# Altium schematic sheet RF19_Sensor_CAN_Module_MCU.SchDoc
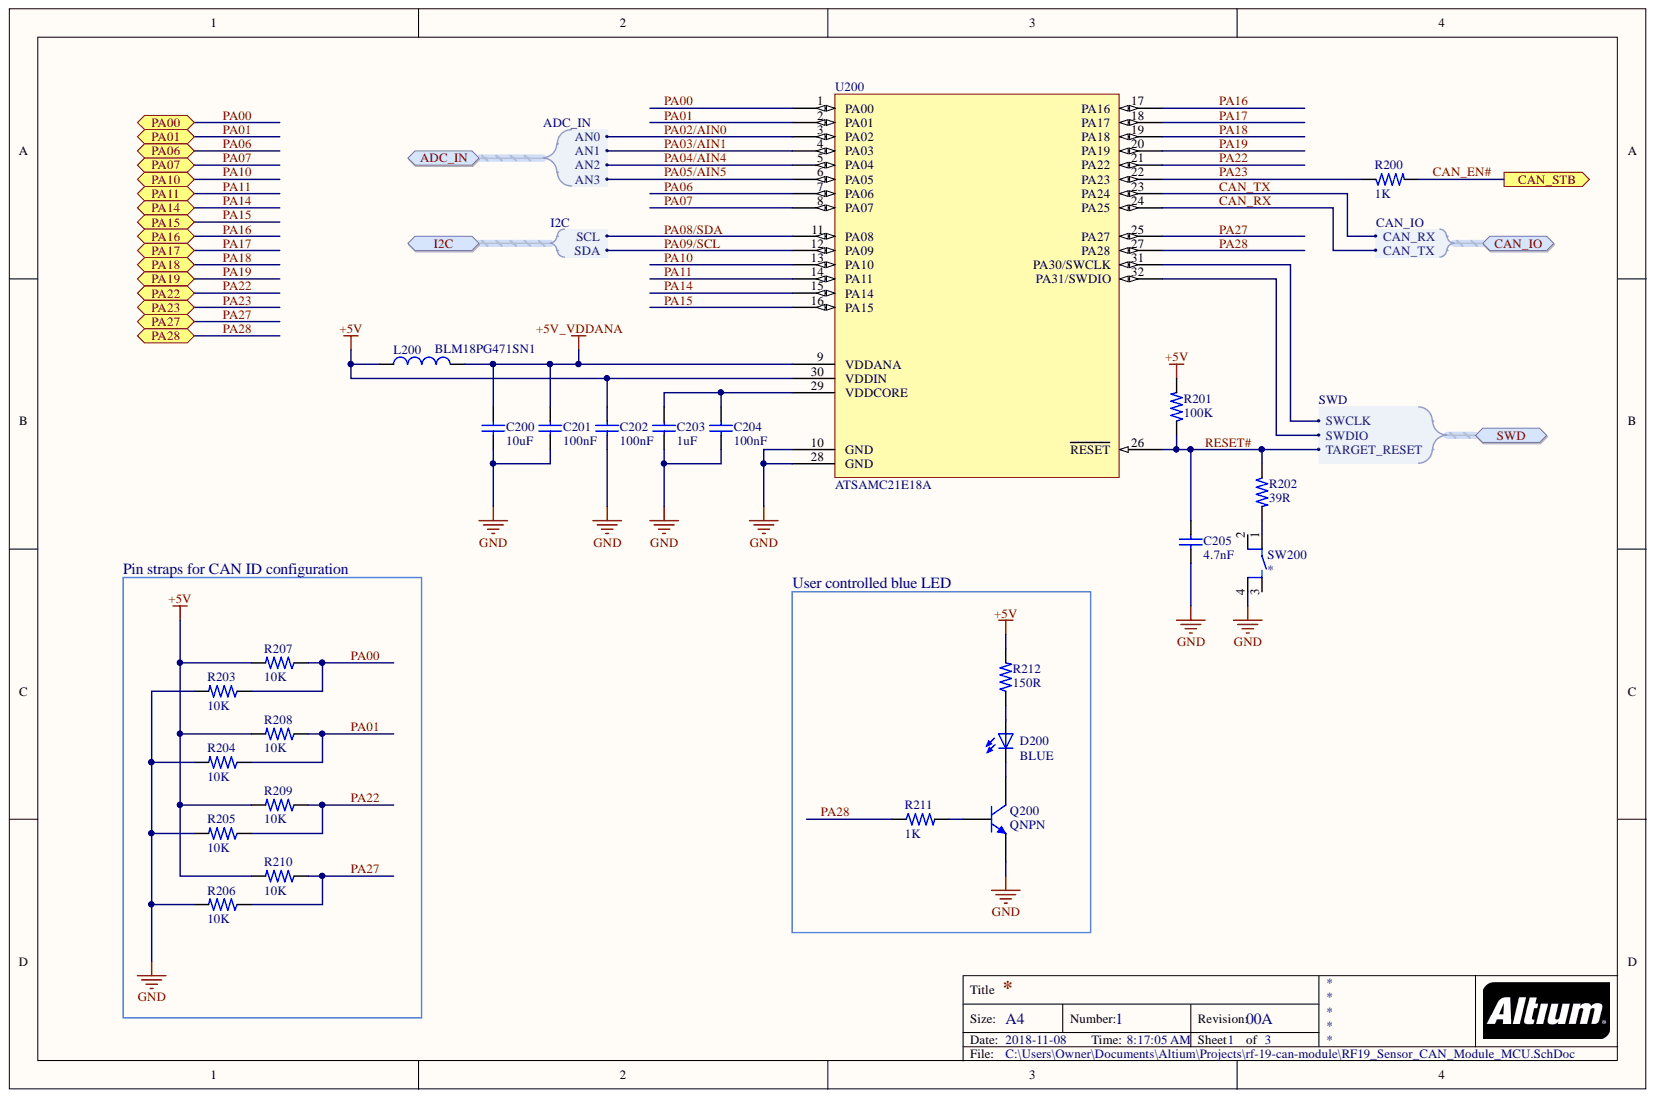
[diagram p0: RF19_Sensor_CAN_Module_MCU.SchDoc]

## Parts
C200  value 10uF  [C0402]  (hidden comment: CAP CERAMIC)
C201  value 100nF  [C0402]  (hidden comment: CAP CERAMIC)
C202  value 100nF  [C0402]  (hidden comment: CAP CERAMIC)
C203  value 1uF  [C0402]  (hidden comment: CAP CERAMIC)
C204  value 100nF  [C0402]  (hidden comment: CAP CERAMIC)
C205  value 4.7nF  [C0402]  (hidden comment: CAP CERAMIC)
D200  BLUE  [0603 LED]
L200  value BLM18PG471SN1  MPN: BLM18PG471SN1  [L0603_MURATA]
Q200  QNPN  [SOT-23B_L]
R200  value 1K  [R0402]  (hidden comment: RESISTOR)
R201  value 100K  [R0402]  (hidden comment: RESISTOR)
R202  value 39R  [R0402]  (hidden comment: RESISTOR)
R203  value 10K  [R0402]  (hidden comment: RESISTOR)
R204  value 10K  [R0402]  (hidden comment: RESISTOR)
R205  value 10K  [R0402]  (hidden comment: RESISTOR)
R206  value 10K  [R0402]  (hidden comment: RESISTOR)
R207  value 10K  [R0402]  (hidden comment: RESISTOR)
R208  value 10K  [R0402]  (hidden comment: RESISTOR)
R209  value 10K  [R0402]  (hidden comment: RESISTOR)
R210  value 10K  [R0402]  (hidden comment: RESISTOR)
R211  value 1K  [R0402]  (hidden comment: RESISTOR)
R212  value 150R  [R0402]  (hidden comment: RESISTOR)
SW200  (no value)  [TACTILE GULL WING KMR7]
U200  ATSAMC21E18A  [ATMEL_TQFP-32]

## Ports
ADC_IN, ADC_IN.AN0, ADC_IN.AN1, ADC_IN.AN2, ADC_IN.AN3, CAN_IO, CAN_IO.CAN_RX, CAN_IO.CAN_TX, CAN_STB, I2C, I2C.SCL, I2C.SDA, PA00, PA01, PA06, PA07, PA10, PA11, PA14, PA15, PA16, PA17, PA18, PA19, PA22, PA23, PA27, PA28, SWD, SWD.SWCLK, SWD.SWDIO, SWD.TARGET_RESET

## Nets
+5V: C202.1 L200.1 R201.2 R207.2 R208.2 R209.2 R210.2 R212.2 U200.30(VDDIN)
+5V_VDDANA: C200.1 C201.1 L200.2 U200.9(VDDANA)
GND: C200.2 C201.2 C202.2 C203.2 C204.2 C205.2 Q200.3 R203.2 R204.2 R205.2 R206.2 SW200.4 U200.10(GND) U200.28(GND)
CAN_EN#: R200.2 ; port CAN_STB
CAN_RX: U200.24(PA25) ; port CAN_IO
CAN_TX: U200.23(PA24) ; port CAN_IO
PA00: R203.1 R207.1 U200.1(PA00) ; port PA00
PA01: R204.1 R208.1 U200.2(PA01) ; port PA01
PA02/AIN0: U200.3(PA02) ; port ADC_IN
PA03/AIN1: U200.4(PA03) ; port ADC_IN
PA04/AIN4: U200.5(PA04) ; port ADC_IN
PA05/AIN5: U200.6(PA05) ; port ADC_IN
PA06: U200.7(PA06) ; port PA06
PA07: U200.8(PA07) ; port PA07
PA08/SDA: U200.11(PA08) ; port I2C
PA09/SCL: U200.12(PA09) ; port I2C
PA10: U200.13(PA10) ; port PA10
PA11: U200.14(PA11) ; port PA11
PA14: U200.15(PA14) ; port PA14
PA15: U200.16(PA15) ; port PA15
PA16: U200.17(PA16) ; port PA16
PA17: U200.18(PA17) ; port PA17
PA18: U200.19(PA18) ; port PA18
PA19: U200.20(PA19) ; port PA19
PA22: R205.1 R209.1 U200.21(PA22) ; port PA22
PA23: R200.1 U200.22(PA23) ; port PA23
PA27: R206.1 R210.1 U200.25(PA27) ; port PA27
PA28: R211.1 U200.27(PA28) ; port PA28
RESET#: C205.1 R201.1 R202.1 U200.26(RESET) ; port SWD
NetC203_1: C203.1 C204.1 U200.29(VDDCORE)
NetD200_A: D200.A R212.1
NetD200_C: D200.C Q200.1
NetQ200_2: Q200.2 R211.2
NetR202_2: R202.2 SW200.1
NetU200_31: U200.31(PA30/SWCLK) ; port SWD
NetU200_32: U200.32(PA31/SWDIO) ; port SWD

Not connected: SW200 2 3

## Library data of the parts
C200-C205 = CAP CERAMIC: Ceramic capacitor; Component Kind: Standard; Library Name: Custom.SchLib
D200 = LED: Standard LED, 5mm or 0603 SMD; Component Kind: Standard; Library Name: Custom.SchLib
L200 = A03-0035: SMD RF inductor 0603. Z=470Ohm (@100MHz), Max R(dc)=0.65Ohm, Max current=1A; Manufacturer: Murata; PartGroup: Inductors,EMI; Database Table Name: AltiumComponents; TemperatureRange: -55°C to +125°C; Tolerance: ±25%; AN: A03-0035; Component Kind: Standard; Height: 0,800000011920929; Library Name: AltiumDbLib.DbLib
Q200 = QNPN: NPN Bipolar Transistor; Component Kind: Standard; LatestRevisionDate: 29-May-2009; LatestRevisionNote: IPC-7351 Footprint Added.; Library Name: Miscellaneous Devices.IntLib; PackageDescription: 3-Pin SOT-23 Package 0.95 mm Pitch, 2.4 mm Lead Span; PackageReference: SOT-23B; PackageVersion: Aug-1999; Published: 8-Jun-2000; Signal Integrity: NPN; Simulation: QNPN; Publisher: Altium Limited
SW200 = SWITCH TACTILE ALT: Tactile Switch SPST-NO Top Actuated Surface Mount; Component Kind: Standard; Library Name: Sensor module used symbols.SchLib; Supplier 1: Digi-Key; Supplier Part Number 1: CKN10689CT-ND
U200 = ATSAMC21E18A: Atmel SAM ARM Cortex-M0+ 32-bit MCU; Component Kind: Standard; Library Name: Custom.SchLib
R200-R212 = RESISTOR: Component Kind: Standard; Description: Resistor; Library Name: Custom.SchLib
